# Altium schematic sheet 03_SRAD_Current_Amplification.SchDoc(03_SRAD_Current_Amplification)
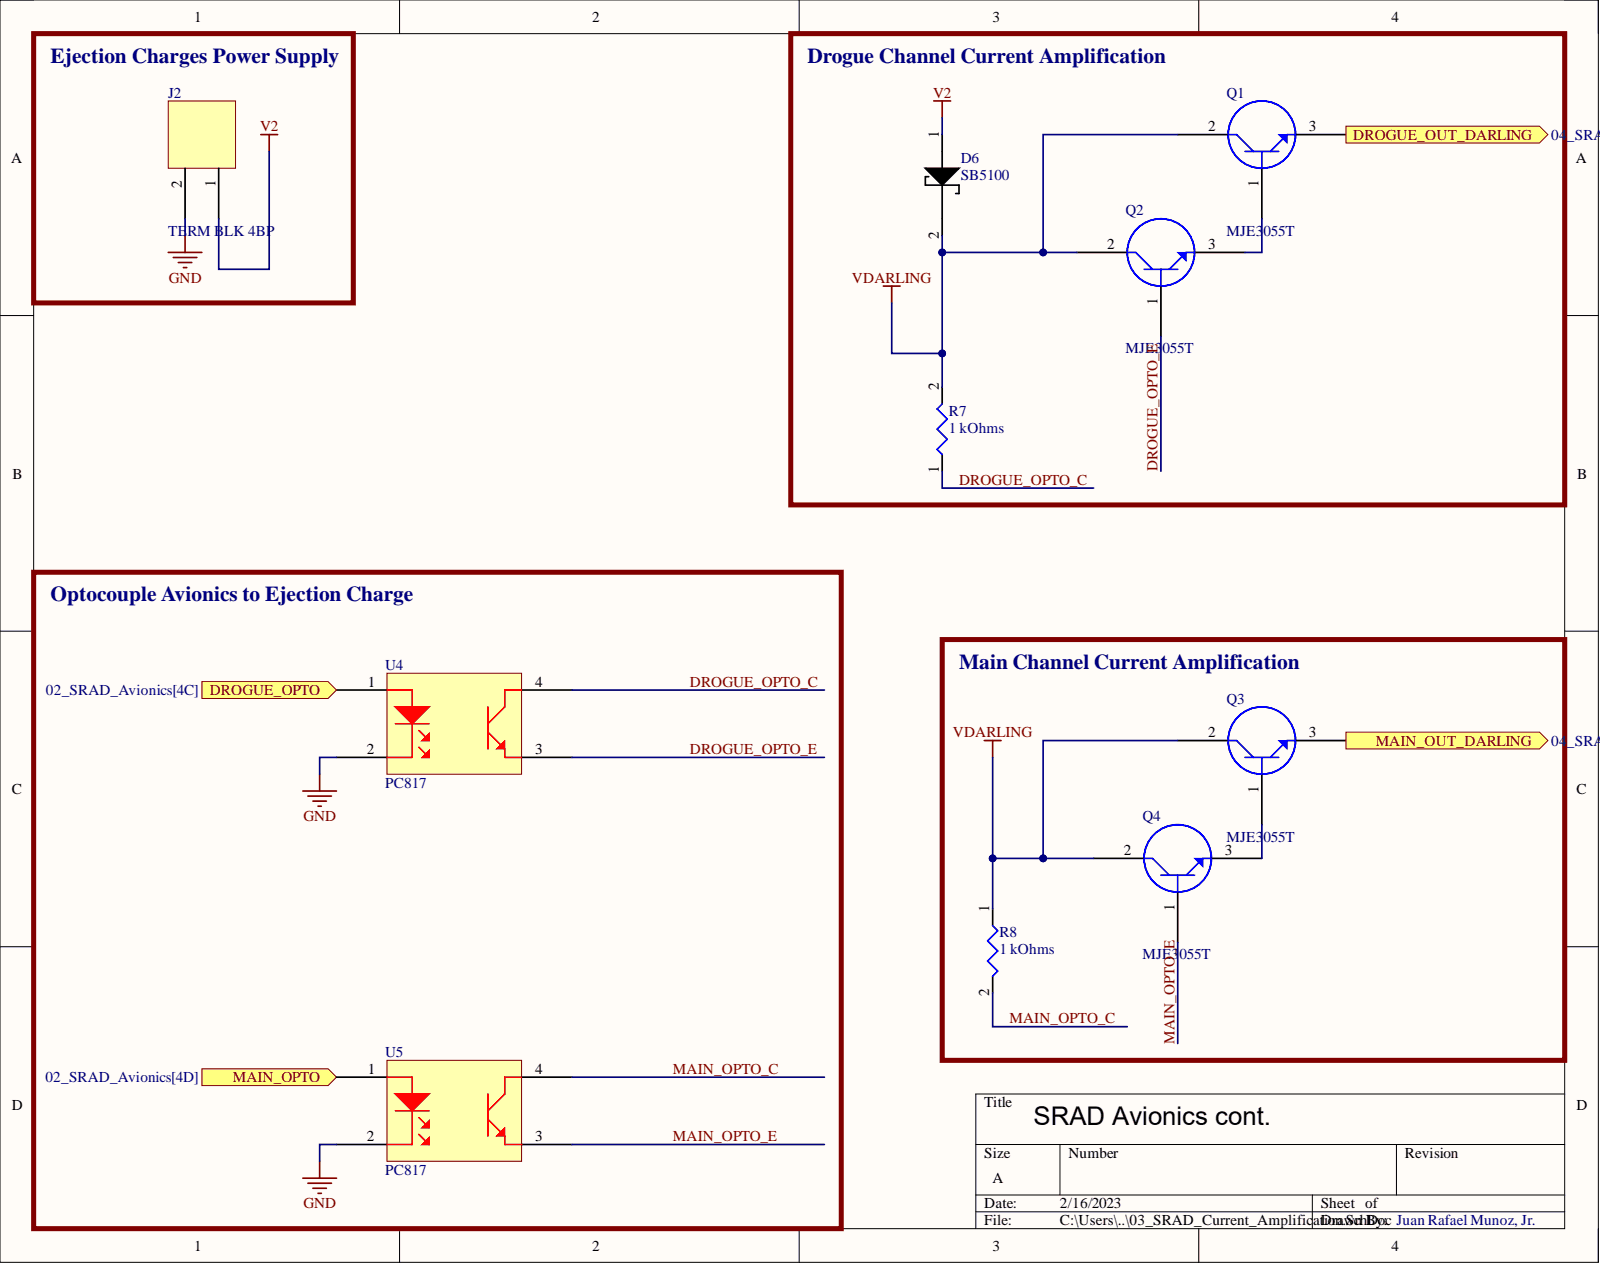
[diagram p0: 03_SRAD_Current_Amplification.SchDoc]

## Parts
D6  SB5100  [SB5100 Schottky Diode]
J2  TERM BLK 4BP  [PCB TERM BLK 2P 2.54MM]
Q1  MJE3055T  [MJE3055T]
Q2  MJE3055T  [MJE3055T]
Q3  MJE3055T  [MJE3055T]
Q4  MJE3055T  [MJE3055T]
R7  1 kOhms  [1 kOhm Yageo SMD]
R8  1 kOhms  [1 kOhm Yageo SMD]
U4  PC817  [PC817]
U5  PC817  [PC817]

## Ports
DROGUE_OPTO, DROGUE_OUT_DARLING, MAIN_OPTO, MAIN_OUT_DARLING

## Nets
GND: J2.2 U4.2 U5.2
V2: D6.1 J2.1
VDARLING: D6.2 Q1.2 Q2.2 Q3.2 Q4.2 R7.2 R8.1
DROGUE_OPTO: U4.1 ; port DROGUE_OPTO
DROGUE_OPTO_C: R7.1 U4.4
DROGUE_OPTO_E: Q2.1 U4.3
DROGUE_OUT_DARLING: Q1.3 ; port DROGUE_OUT_DARLING
MAIN_OPTO: U5.1 ; port MAIN_OPTO
MAIN_OPTO_C: R8.2 U5.4
MAIN_OPTO_E: Q4.1 U5.3
MAIN_OUT_DARLING: Q3.3 ; port MAIN_OUT_DARLING
NetQ1_1: Q1.1 Q2.3
NetQ3_1: Q3.1 Q4.3

## Library data of the parts
D6 = SB5100 Schottky Diode: Diode 100 V 5A Through Hole; Manufacturer: ON Semiconductor / Fairchild; Element Configuration: Single; Forward Voltage: 850mV; Max Forward Surge Current (Ifsm): 100A; Max Repetitive Reverse Voltage (Vrrm): 100V; Max Reverse Current: 500uA; Max Surge Current: 150A; Polarity: Standard; Average Rectified Current: 5A; Case/Package: DO-201AD; Category: Uncategorized; Component Kind: Standard; ComponentLink1Description: https://datasheet.ciiva.com/911/sb5100-911093.pdf?src-supplier=Avnet; ComponentLink1URL: https://datasheet.ciiva.com/911/sb5100-911093.pdf?src-supplier=Avnet; ComponentLink2Description: https://datasheet.ciiva.com/4714/sb5100-191746-4714390.pdf?src-supplier=Mouser; ComponentLink2URL: https://datasheet.ciiva.com/4714/sb5100-191746-4714390.pdf?src-supplier=Mouser; ComponentLink3Description: https://datasheet.ciiva.com/7965/78621-7965207.pdf?src-supplier=Element14; ComponentLink3URL: https://datasheet.ciiva.com/7965/78621-7965207.pdf?src-supplier=Element14; ComponentLink4Description: https://datasheet.ciiva.com/911/sb5100-911279.pdf?src-supplier=Digi-Key; ComponentLink4URL: https://datasheet.ciiva.com/911/sb5100-911279.pdf?src-supplier=Digi-Key; ComponentLink5Description: https://datasheet.ciiva.com/911/sb5100-911278.pdf?src-supplier=Digi-Key; ComponentLink5URL: https://datasheet.ciiva.com/911/sb5100-911278.pdf?src-supplier=Digi-Key; Current Rating: 5A; Diameter: 5.6mm; Forward Current: 5A; Height: 9.5mm; Lead Free: Lead Free; Length: 0.25inch; Manufacturer Part Number: SB5100; Max Operating Temperature: 150°C; Max Reverse Leakage Current: 500uA; Max Reverse Voltage (DC): 100V; Min Operating Temperature: -50°C; Mounting Technology: Through Hole; Output Current: 5A; Package Quantity: 1; Packaging: Tape and Reel; Peak Non-Repetitive Surge Current: 150A; Pins: 2; Pricing 1: 1=1.21000 (USD); Radiation Hardening: No; Reach SVHC Compliant: Yes; RoHS: Yes; RoHS Compliant: Yes; Series: -; Stock 1: 1; Supplier 1: Digi-Key; Supplier Part Number 1: SB5100FSCT-ND; Voltage Rating (DC): 100V; Weight: 0.36g; Width: 0.25inch; Library Name: SRAD_SAC_Schlib.SchLib
J2 = PCB TERM BLK 2P 2.54MM: 2 Position Wire to Board Terminal Block Horizontal with Board 0.100" (2.54mm) Through Hole 10A 150V 16-30 AWG 0.2 Nm M1.6 screw -40°C ~ 105°C; Manufacturer: TE Connectivity; Manufacturer Part Number: 282834-2; Approvals: UL; Closed End: No (Without); Connector Type: Receptacle; Gender: Male; Number of Circuits: 2; Number of Levels: 1; Plating: Brass,Steel; Screwless Terminal Block: Yes (With); Tail Length: 3.4mm; Wire Gauge (Max): 30AWG; Category: Terminal Blocks; Color: Green; Component Kind: Standard; ComponentLink1Description: https://datasheet.ciiva.com/16386/282834-2-16386363.pdf?src-supplier=Future+Electronics; ComponentLink1URL: https://datasheet.ciiva.com/16386/282834-2-16386363.pdf?src-supplier=Future+Electronics; ComponentLink2Description: https://datasheet.ciiva.com/30166/eng-cs-1308389-eurostyle-terminal-blocks-0607-30166974.pdf?src-supplier=Onlinecomponents.com; ComponentLink2URL: https://datasheet.ciiva.com/30166/eng-cs-1308389-eurostyle-terminal-blocks-0607-30166974.pdf?src-supplier=Onlinecomponents.com; ComponentLink3Description: https://datasheet.ciiva.com/14609/70086732-14609670.pdf?src-supplier=Allied+Electronics; ComponentLink3URL: https://datasheet.ciiva.com/14609/70086732-14609670.pdf?src-supplier=Allied+Electronics; ComponentLink4Description: https://datasheet.ciiva.com/15169/getdatasheetpartid-155864-15169558.pdf?src-supplier=Verical; ComponentLink4URL: https://datasheet.ciiva.com/15169/getdatasheetpartid-155864-15169558.pdf?src-supplier=Verical; ComponentLink5Description: https://datasheet.ciiva.com/3141/eng-cs-1308389-eurostyle-terminal-blocks-0607-3141115.pdf?src-supplier=Avnet; ComponentLink5URL: https://datasheet.ciiva.com/3141/eng-cs-1308389-eurostyle-terminal-blocks-0607-3141115.pdf?src-supplier=Avnet; Contact Material: Brass; Contact Plating: Tin; Current: 10A; Current Rating: 10A; Depth: 6.5mm; ELV: Compliant; Flammability Rating: UL94 V-0; Housing Color: Green; Housing Material: Polyamide; Interlock: No (Without); Lead Free: Lead Free; Length: 5.54mm; Material: Nylon; Max Current Rating: 10A; Max Operating Temperature: 105°C; Max Supply Voltage (AC): 150V; Max Voltage Rating (AC): 150V; Min Operating Temperature: -40°C; Mounting Technology: Through Hole; Number of Contacts: 2; Number of Poles: 2; Number of Positions: 2; Number of Positions Per Level: 2; Number of Rows: 1; Orientation: Straight; Package Quantity: 300; Packaging: Bulk; Pitch: 2.54mm; Pricing 1: 1=1.95000, 10=1.47400, 25=1.36640, 50=1.22860, 100=1.19860, 250=1.04876, 500=0.95886, 1000=0.86896, 5000=0.76409 (USD); Radiation Hardening: No; RoHS: Yes; RoHS Compliant: Yes; Screw Flange: No (Without); Series: Buchanan; Side Stackable: Yes (With); Stock 1: 34508; Supplier 1: Digi-Key; Supplier Part Number 1: A98333-ND; Termination: Solder; Thread Size: M1.6; Voltage: 150V; Voltage Rating: 150V; Width: 6.5mm; Wire Gauge (Min): 30AWG; Wire/Cable Gauge: 30AWG; Library Name: SRAD_SAC_Schlib.SchLib
Q1-Q4 = MJE3055T: Bipolar (BJT) Transistor NPN 60 V 10 A 2MHz 75 W Through Hole; Component Kind: Standard; Library Name: SRAD_SAC_Schlib.SchLib
R7 R8 = 1 kOhm Yageo SMD: 1 kOhms ±1% 1W Chip Resistor 2512 (6432 Metric) Moisture Resistant Thick Film; Manufacturer: Yageo; Manufacturer Part Number: RC2512FK-071KL; Temperature Coefficient: 100ppm/°C; Value: 1kR; Voltage Rating: 200V; Case Code (Imperial): 2512; Case Code (Metric): 6432; Case/Package: 2512; Category: Chip SMD Resistors; Component Kind: Standard; ComponentLink1Description: https://datasheet.ciiva.com/7671/638316-7671963.pdf?src-supplier=Element14; ComponentLink1URL: https://datasheet.ciiva.com/7671/638316-7671963.pdf?src-supplier=Element14; ComponentLink2Description: https://datasheet.ciiva.com/7671/638316-7671962.pdf?src-supplier=Element14; ComponentLink2URL: https://datasheet.ciiva.com/7671/638316-7671962.pdf?src-supplier=Element14; ComponentLink3Description: https://datasheet.ciiva.com/12555/pyu-rc2512-51-rohs-l-4-12555290.pdf?src-supplier=Mouser; ComponentLink3URL: https://datasheet.ciiva.com/12555/pyu-rc2512-51-rohs-l-4-12555290.pdf?src-supplier=Mouser; ComponentLink4Description: https://datasheet.ciiva.com/8896/pyu-rc2512-51-rohs-l-4-8896186.pdf?src-supplier=Avnet; ComponentLink4URL: https://datasheet.ciiva.com/8896/pyu-rc2512-51-rohs-l-4-8896186.pdf?src-supplier=Avnet; ComponentLink5Description: https://datasheet.ciiva.com/17421/pyu-rc2512-51-rohs-l-4-17421912.pdf?src-supplier=Future+Electronics; ComponentLink5URL: https://datasheet.ciiva.com/17421/pyu-rc2512-51-rohs-l-4-17421912.pdf?src-supplier=Future+Electronics; Construction: Rectangular; Contact Plating: Tin,Matte; Depth: 3.1mm; Features: Moisture Resistant; Height: 0.026inch; Lead Free: Lead Free; Length: 0.25inch; Max Operating Temperature: 155°C; Max Power Dissipation: 1W; Military Standard: Not; Min Operating Temperature: -55°C; Number of Terminations: 2; Packaging: Tape and Reel; Power Rating: 1W; Pricing 1: 4000=0.05361, 8000=0.04850, 12000=0.04629, 28000=0.04435, 100000=0.04255 (USD); Radiation Hardening: No; Resistance Tolerance: 1%; Resistor Type: Thick Film; RoHS: Yes; RoHS Compliant: Yes; Series: RC; Stock 1: 4000; Supplier 1: Digi-Key; Supplier Part Number 1: YAG3390TR-ND; Termination: SMD/SMT; Tolerance: 1%; Width: 3.1mm; Library Name: SRAD_SAC_Schlib.SchLib
U4 U5 = PC817: Photocoupler output: phototransistor, DIP4 2.54mm, Forward current (ICEO): 50mA Forward peak current (ICE max) :1A Reverse voltage :6V Power consumption: 70mW Collector emitter voltage :35V Emitter collector voltage: 6V Collector current: 50mA Collector power consumption: 150mW Total power consumption: 200mW Working temperature: -30℃~+100℃ Collector emitter saturation voltage: 0.1V (typical value); Component Kind: Standard; Library Name: SRAD_SAC_Schlib.SchLib
